# Altium schematic sheet 1819D_Emetteur_DCF.SchDoc
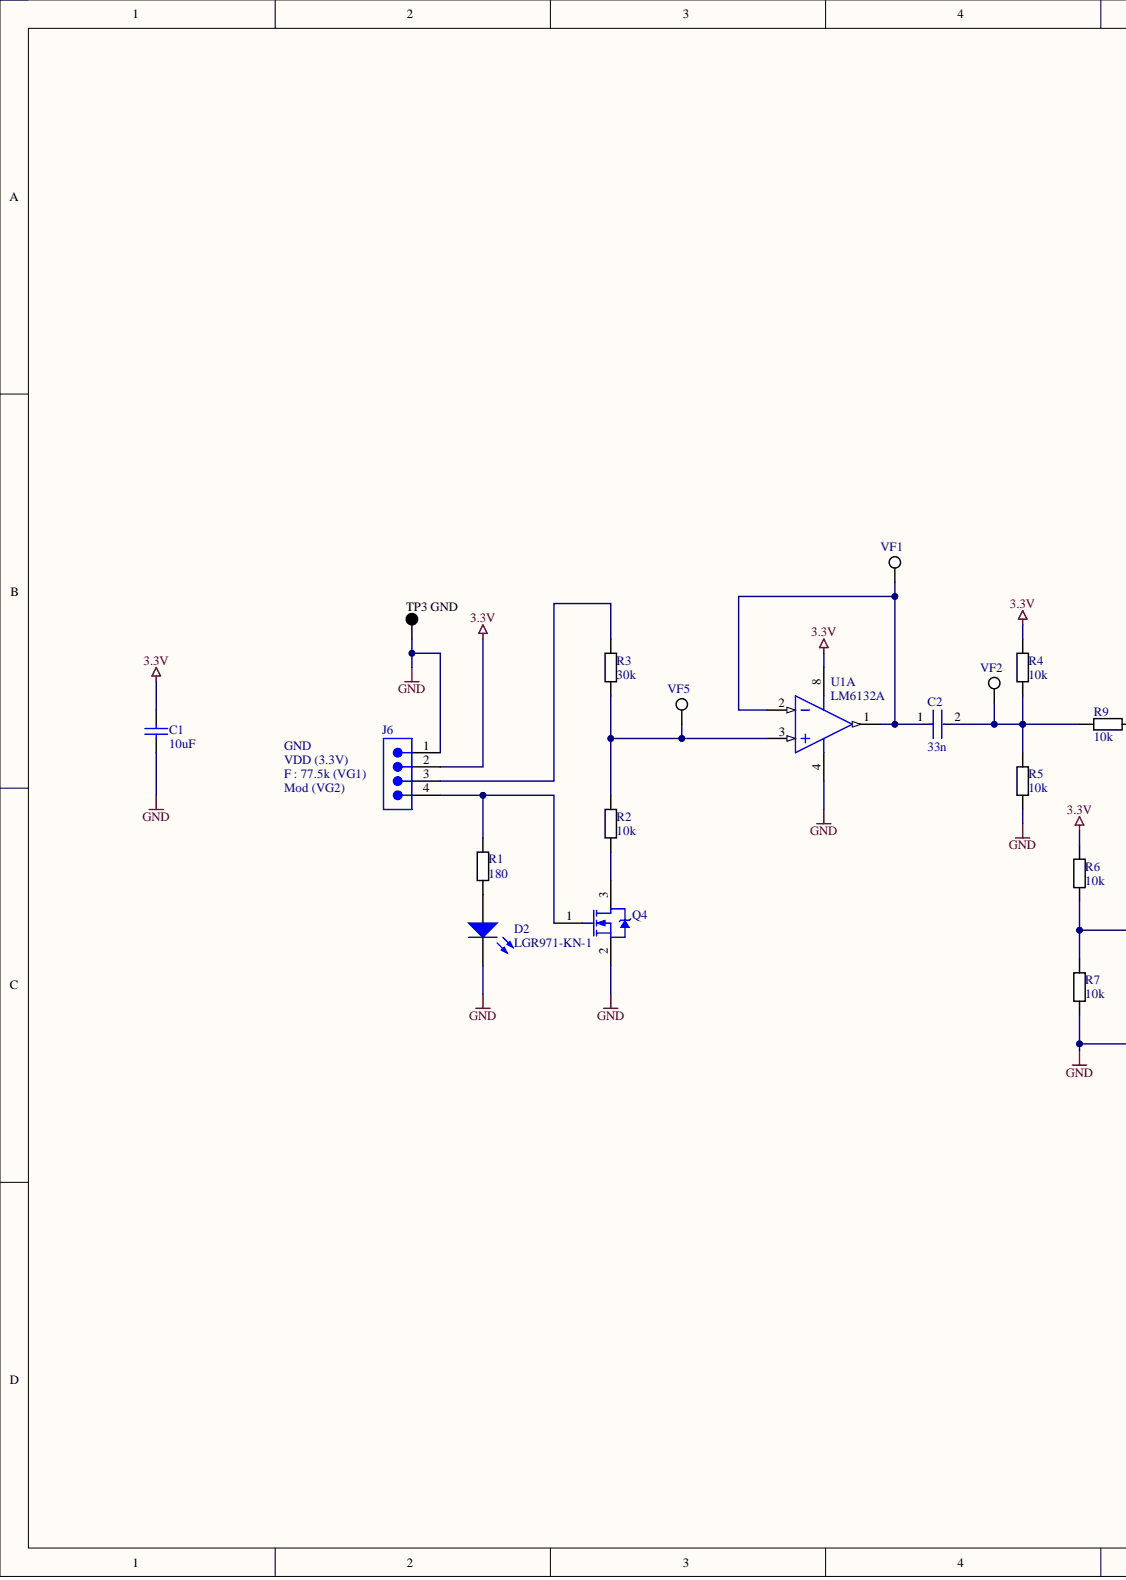
[diagram p0: 1819D_Emetteur_DCF.SchDoc, left]
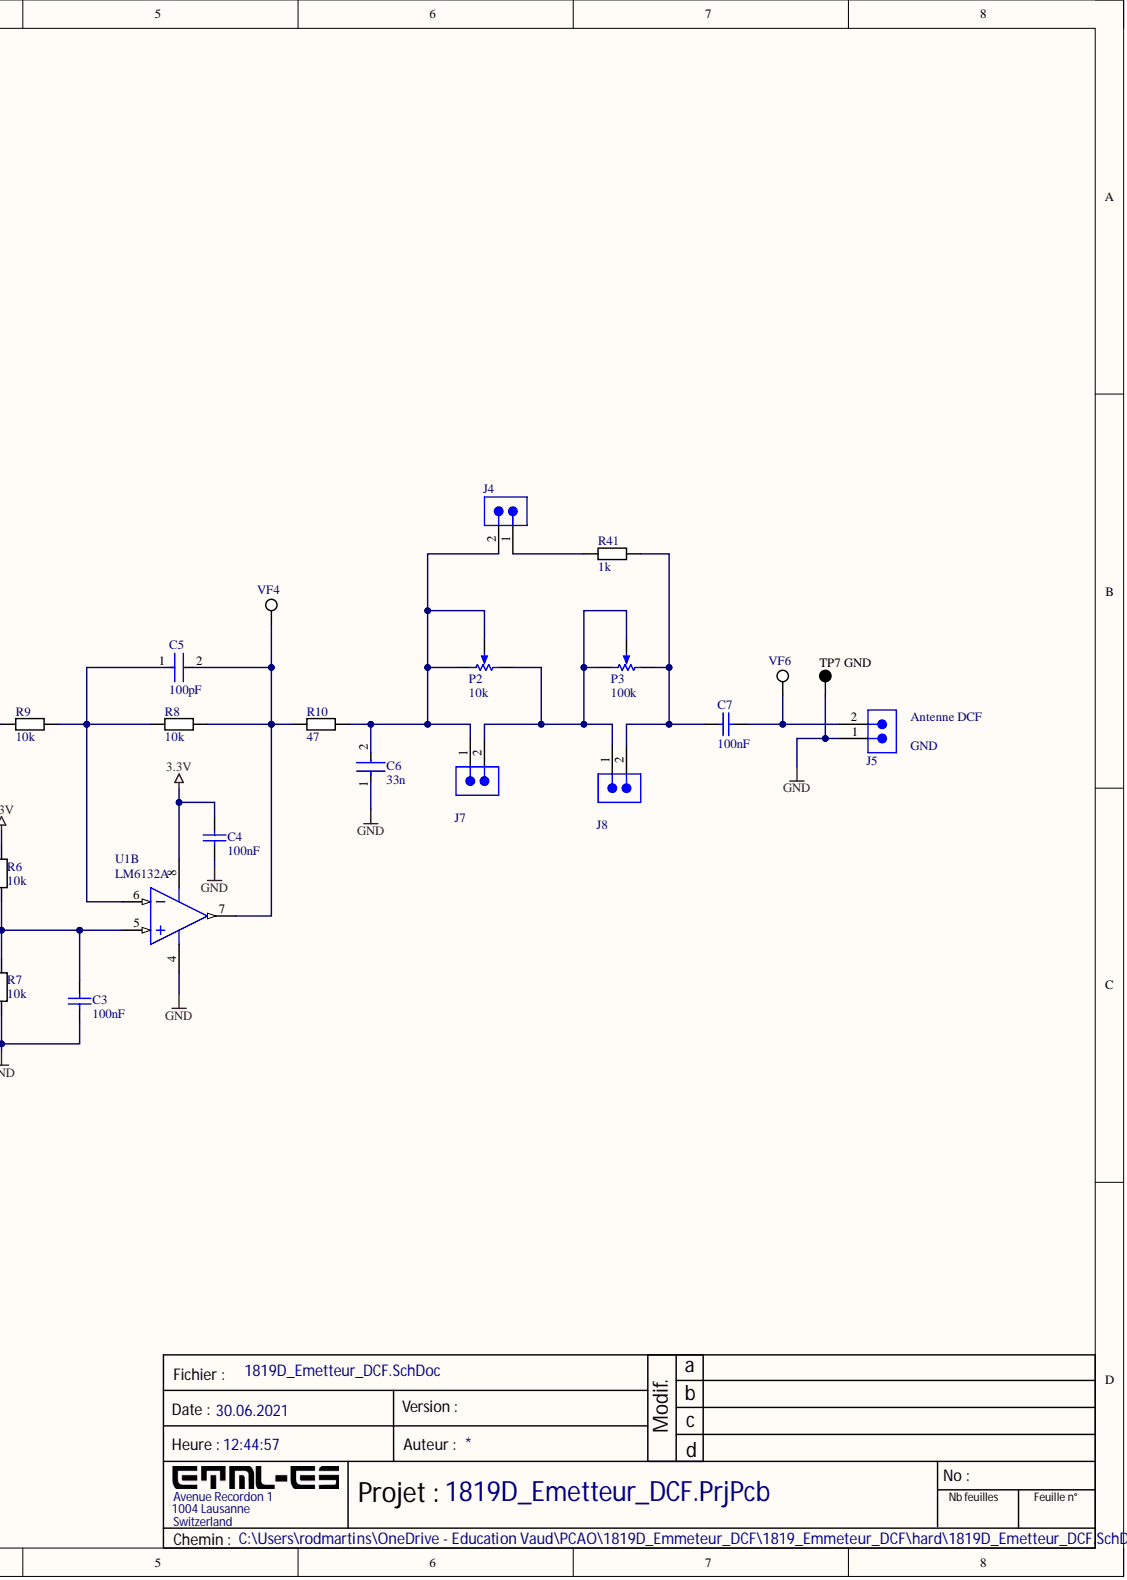
[diagram p1: 1819D_Emetteur_DCF.SchDoc, right]

## Parts
C1  10uF  [CAPC2013X135X45NL10T25]
C2  33n  [FP-C1206C-EB-IPC_B]
C3  100nF  [CAPC2013X140X50LL20T25]
C4  100nF  [CAPC2013X140X50LL20T25]
C5  100pF  [FP-C0805C-DN-MFG]
C6  33n  [FP-C1206C-EB-IPC_B]
C7  100nF  [CAPC2013X140X50LL20T25]
D2  LGR971-KN-1  [LED_0805]
J4  TSW-102-26-L-S  [SMTC-TSW-102-26-XX-S]  (value not drawn)
J5  TSW-102-26-L-S  [SMTC-TSW-102-26-XX-S]  (value not drawn)
J6  TSW-104-08-G-S  [SMTC-TSW-104-08-X-S]  (value not drawn)
J7  TSW-102-26-L-S  [SMTC-TSW-102-26-XX-S]  (value not drawn)
J8  TSW-102-26-L-S  [SMTC-TSW-102-26-XX-S]  (value not drawn)
P2  10k  [BOUR-3362P]
P3  100k  [BOUR-3362P]
Q4  IRLML2402TRPBF  [INTR-IRLML2402TRPBF-3_V]  (value not drawn)
R1  180  [RESC2013X50X30NL20T20]
R2  10k  [RESC2013X50X30NL20T20]
R3  30k  [RESC2013X50X30NL20T20]
R4  10k  [RESC2013X50X30NL20T20]
R5  10k  [RESC2013X50X30NL20T20]
R6  10k  [RESC2013X50X30NL20T20]
R7  10k  [RESC2013X50X30NL20T20]
R8  10k  [RESC2013X50X30NL20T20]
R9  10k  [RESC2013X50X30NL20T20]
R10  47  [RESC2013X50X30NL20T20]
R41  1k  [RESC2013X50X30NL20T20]
TP3_GND  5011  [KSTN-5011_V]  (value not drawn)
TP7_GND  5011  [KSTN-5011_V]  (value not drawn)
U1  LM6132A  [D0008A_N]  (parts A,B)
VF1  5019  [KSTN-5019_V]  (value not drawn)
VF2  5019  [KSTN-5019_V]  (value not drawn)
VF4  5019  [KSTN-5019_V]  (value not drawn)
VF5  5019  [KSTN-5019_V]  (value not drawn)
VF6  5019  [KSTN-5019_V]  (value not drawn)

## Nets
3.3V: C1.2 C4.2 J6.2 R4.1 R6.2 U1.8
GND: C1.1 C3.1 C4.1 C6.1 D2.1 J5.1 J6.1 Q4.2 R5.2 R7.1 TP3_GND.1 TP7_GND.1 U1.4
NetC2_1: C2.1 U1.1 U1.2 VF1.1
NetC2_2: C2.2 R4.2 R5.1 R9.1 VF2.1
NetC3_2: C3.2 R6.1 R7.2 U1.5
NetC5_1: C5.1 R8.1 R9.2 U1.6
NetC5_2: C5.2 R8.2 R10.1 U1.7 VF4.1
NetC6_2: C6.2 J4.2 J7.1 P2.2 P2.3 R10.2
NetC7_1: C7.1 J5.2 VF6.1
NetC7_2: C7.2 J8.2 P3.1 R41.2
NetD2_2: D2.2 R1.2
NetJ4_1: J4.1 R41.1
NetJ6_3: J6.3 R3.1
NetJ6_4: J6.4 Q4.1 R1.1
NetJ7_2: J7.2 J8.1 P2.1 P3.2 P3.3
NetQ4_3: Q4.3 R2.2
NetR2_1: R2.1 R3.2 U1.3 VF5.1

## Library data of the parts
C1 = CMP-2007-03514-1: Manufacturer: Murata; Part Number: GRM21BF51C106ZE15L; Component Kind: Standard; Library Name: Altium Content Vault
C2 C6 = CMP-03020-013789-1: Multilayer Ceramic Capacitor, C Series, 0.033 - F, - 10%, X7r, 50 V, 1206 [3216 Metric]; Manufacturer: KEMET; Manufacturer Part Number: C1206C333K5RACTU; Automotive Grade: Grade 0; Capacitance: 33,000 pF; Temperature Coefficient: 15%; Tolerance: 0.1; Value: 33,000 pF; Voltage Rating: 50 V; Automotive: Yes; Category: Cap; Component Kind: Standard; ComponentLink2Description: Datasheet; ComponentLink2URL: https://content.kemet.com/datasheets/KEM_C1007_X8R_ULTRA_150C_SMD.pdf; Device Class L1: Passive Components; Device Class L2: Capacitors; Device Class L3: Ceramic Capacitors; Digikey Description: Multilayer Ceramic Capacitor, C Series, 0.033 - F, - 10%, X7r, 50 V, 1206 [3216 Metric]; Height: 0.88mm; Ipc Land Pattern Name: CAPC320160X78; Lead Free: Yes; Library Name: Altium Content Vault; Material: Ceramic; Mouser Description: Multilayer Ceramic Capacitor, C Series, 0.033 - F, - 10%, X7r, 50 V, 1206 [3216 Metric]; Octopart: https://octopart.com/c1206c333k5ractu-kemet-125953; Package: C1206C-EB; RoHS: TRUE; Temperature: -55°C to +150°C; Temperature Characteristic: X7R; Temperature Range High: +150°C; Temperature Range Low: -55°C
C3 C4 C7 = CMP-2007-04556-1: Manufacturer: AVX Interconnect / Elco; Part Number: 08051A470JAT2A; Component Kind: Standard; Library Name: Altium Content Vault
C5 = CMP-03020-013647-1: CAP CER 100PF 50V C0G/NP0 0805; Manufacturer: KEMET; Manufacturer Part Number: C0805C101J5GACTU; Material: Ceramic; Temperature Characteristic: C0G; Temperature Coefficient: 30ppm/°C; Tolerance: 0.05; Automotive: No; Capacitance: 100 pF; Category: Cap; Component Kind: Standard; ComponentLink2Description: Datasheet; ComponentLink2URL: https://content.kemet.com/datasheets/KEM_C1003_C0G_SMD.pdf; Device Class L1: Passive Components; Device Class L2: Capacitors; Device Class L3: Ceramic Capacitors; Digikey Description: CAP CER 100PF 50V C0G/NP0 0805; Height: 0.88mm; Ipc Land Pattern Name: CAPC200125X78; Lead Free: Yes; Library Name: Altium Content Vault; Octopart: https://octopart.com/c0805c101j5gactu-kemet-100795; Package: C0805C-DN; RoHS: TRUE; Temperature: -55°C to +125°C; Temperature Range High: +125°C; Temperature Range Low: -55°C; Value: 100 pF; Voltage Rating: 50 V
D2 = LGR971-KN-1: SMD mono-color Chip LED, 0805, Green; Manufacturer: Wuerth Elektronik; Color: Green; Component Kind: Standard; ComponentLink1Description: Manufacturer URL; ComponentLink2Description: Datasheet; Library Name: Local_Lib.SchLib; PackageReference: 0805_A; PartNumber: LGR971-KN-1; Supplier: Stock ES; Value: LGR971-KN-1
J4 J5 J7 J8 = CMP-1024-00330-1: 0.025" SQ Post Header, Through-hole, Vertical, -55 to 125 degC, 2.54 mm Pitch, 2-Pin, Male, RoHS; Manufacturer: Samtec; Pitch: 2.54 mm; Component Kind: Standard; ComponentLink1Description: Manufacturer URL; ComponentLink1URL: http://www.samtec.com; ComponentLink2Description: Datasheet; ComponentLink2URL: http://www.samtec.com/documents/webfiles/pdf/TSW_TH.PDF; ComponentLink3Description: Package Specification; ComponentLink3URL: http://www.samtec.com/documents/webfiles/cpdf/TSW-XXX-XX-XXX-X-XX-XXX-MKT.pdf; DatasheetVersion: F-214; Library Name: Altium Content Vault; Mounting Technology: Through-hole; Orientation: Vertical; PackageDescription: .025[0.64] SQ. Post Terminal Strip Assembly; PackageReference: TSW-102-26-XX-S; PackageVersion: DM, 8/1988; PartNumber: TSW-102-26-L-S; RoHS: True; Temperature Range: -55 to 125 degC
J6 = CMP-1024-00357-1: 0.025" SQ Post Header, Through-hole, Vertical, -55 to 125 degC, 2.54 mm Pitch, 4-Pin, Male, RoHS; Manufacturer: Samtec; Pitch: 2.54 mm; Component Kind: Standard; ComponentLink1Description: Manufacturer URL; ComponentLink1URL: http://www.samtec.com; ComponentLink2Description: Datasheet; ComponentLink2URL: http://www.samtec.com/documents/webfiles/pdf/TSW_TH.PDF; ComponentLink3Description: Package Specification; ComponentLink3URL: http://www.samtec.com/documents/webfiles/cpdf/TSW-XXX-XX-XXX-X-XX-XXX-MKT.pdf; DatasheetVersion: F-214; Library Name: Altium Content Vault; Mounting Technology: Through-hole; Orientation: Vertical; PackageDescription: .025[0.64] SQ. Post Terminal Strip Assembly; PackageReference: TSW-104-08-X-S; PackageVersion: DM, 8/1988; PartNumber: TSW-104-08-G-S; RoHS: True; Temperature Range: -55 to 125 degC
P2 = CMP-1747-00004-1: Square Trimpot(R) Trimming Potentiometer, 10 KOhm, 300 V, -55 to 125 degC, 3-Pin THD, RoHS, Tube; Power Rating: 1 W; Resistance: 50 mohm; Tolerance: 1%; Voltage Rating: 220 mV; Case Code (Imperial): 0612; Case Code (Metric): 1632; Case/Package: 1206; Component Kind: Standard; ComponentLink1Description: Manufacturer URL; ComponentLink1URL: http://www.bourns.com/; ComponentLink2Description: Datasheet; ComponentLink2URL: http://www.bourns.com/docs/Product-Datasheets/3362.pdf; DatasheetVersion: May-16; Height: 0.6 mm; Length: 3.2 mm; Library Name: Altium Content Vault; Max Operating Temperature: 125degC; Min Operating Temperature: -25degC; Mount: Surface Mount; Package Quantity: 5000; PackageDescription: 3-Pin Through Hole Device, Body 6.6 x 6.99 mm, Pitch 2.54 mm; PackageReference: 3326P; Packaging: Tape and Reel; Pins: 2; REACH SVHC: No SVHC; RoHSCompliant: True; Width: 1.6 mm
P3 = CMP-1747-00003-1: Square Trimpot(R) Trimming Potentiometer, 100 KOhm, 300 V, -55 to 125 degC, 3-Pin THD, RoHS, Tube; Case\Package: Axial; Power Rating: 250 mW; Resistance: 1 mohm; Tolerance: 1%; Voltage Rating: 250 V; Component Kind: Standard; ComponentLink1Description: Manufacturer URL; ComponentLink1URL: http://www.bourns.com/; ComponentLink2Description: Datasheet; ComponentLink2URL: http://www.bourns.com/docs/Product-Datasheets/3362.pdf; DatasheetVersion: May-16; Length: 0.248 inch; Library Name: Altium Content Vault; Max Operating Temperature: 155 degC; Min Operating Temperature: -55 degC; Mount: Through Hole; Package Quantity: 200; PackageDescription: 3-Pin Through Hole Device, Body 6.6 x 6.99 mm, Pitch 2.54 mm; PackageReference: 3326P; Packaging: Bulk; RoHSCompliant: true
Q4 = CMP-2000-05155-1: HEXFET(R) Power MOSFET, N-Channel, 20 V, 1.2 A, 3-Pin SOT-23, RoHS, Tape and Reel; Manufacturer: International Rectifier; Continuous Drain Current Id: 1.2A; Current Temperature: 25degC; Drain Source Voltage Vds: 20V; External Depth: 2.5mm; External Length / Height: 1.12mm; External Width: 3.05mm; Junction Temperature Tj Min: -55degC; No. of Pins: 3; No. of Transistors: 1; On Resistance Rds(on): 250mohm; Power Dissipation Pd: 540mW; Pulse Current Idm: 7.4A; Rds(on) Test Voltage Vgs: 4.5V; Reel Quantity: 3000; SMD Marking: 1A; SVHC: NoSVHC(19-Dec-2012); Threshold Voltage Vgs Typ: 700mV; Transistor Polarity: NChannel; Component Kind: Standard; Current Id Max: 1.2A; Datasheet URL: https://datasheet.ciiva.com/29326/162826-da-01-en-irlml-2402tr-29326791.pdf; Datasheet Version: Jan-03; Full Power Rating Temperature: 25degC; Library Name: Altium Content Vault; Manufacturer URL: http://internationalrectifier.com/; Operating Temperature Range: -55degCto+150degC; Package / Case: SOT-23; Tape Width: 8mm; Termination Type: SMD; Transistor Case Style: SOT-23; Voltage Vds Typ: 20V; Voltage Vgs Max: 700mV; Voltage Vgs Rds on Measurement: 4.5V; Voltage Vgs th Min: 700mV
R1-R10 R41 = Resistance: Component Kind: Standard; Library Name: LibComposant1819.SchLib
TP3_GND TP7_GND = CMP-1672-00003-4: Test Point, Black, Through Hole, RoHS, Bulk; Manufacturer: Keystone; Colour: Black; Component Kind: Standard; ComponentLink1Description: Manufacturer URL; ComponentLink1URL: http://www.keyelco.com/; ComponentLink2Description: Datasheet; ComponentLink2URL: http://www.keyelco.com/product-pdf.cfm?p=1320; Contact Material: Bronze; Contact Plating: Silver; DatasheetVersion: Rev. F, 12/2013; Height: 8.12 mm; Library Name: Altium Content Vault; Mount: Through Hole; Mounting Technology: Through Hole; Package Quantity: 100; PackageDescription: 1-Pin Through Hole Device, Dia 3.17 mm; PackageReference: 5011; Packaging: Bulk; Pins: 1; REACH SVHC: No SVHC; RoHSCompliant: true
U1 = CMP-0055-00786-3: Dual Low Power 10 MHz Rail-to-Rail I/O Operational Amplifier, 8-pin Narrow SOIC, Pb-Free; Manufacturer: Texas Instruments; CompType: Amplifiers; PackageTopMarking: NSZXTT*LM61*32AIM; PowerWise: N; Code_IPC: SOIC127P600-8; Code_JEDEC: MS-012-AA; Component Kind: Standard; ComponentLink1Description: Datasheet URL; ComponentLink1URL: http://www.ti.com/general/docs/lit/getliterature.tsp?genericPartNumber=LM6132&fileType=pdf; Library Name: Altium Content Vault; Package Reference: D0008A; PackageDescription: SOIC Narrow, 4.9 x 3.9 x 1.45mm, 8 Lead, 1.27mm Pitch; PinCount: 8; RoHS: Y
VF1 VF2 VF4-VF6 = CMP-1672-00008-1: Test Point, 1 Position SMD, RoHS, Tape and Reel; Component Kind: Standard; ComponentLink1Description: Manufacturer URL; ComponentLink1URL: http://www.keyelco.com/; ComponentLink2Description: Datasheet; ComponentLink2URL: http://www.keyelco.com/product-pdf.cfm?p=1357; Contact Plating: Silver; DatasheetVersion: 03/2014; Library Name: Altium Content Vault; Mount: Surface Mount; Mounting Technology: Surface Mount; Package Quantity: 1000; PackageDescription: 1-Pin Surface Mount Device, Body 3.81 x 2.03 mm; PackageReference: 5019; Packaging: Tape and Reel; Pins: 1; RoHSCompliant: True
